# Altium schematic sheet ISource.SchDoc
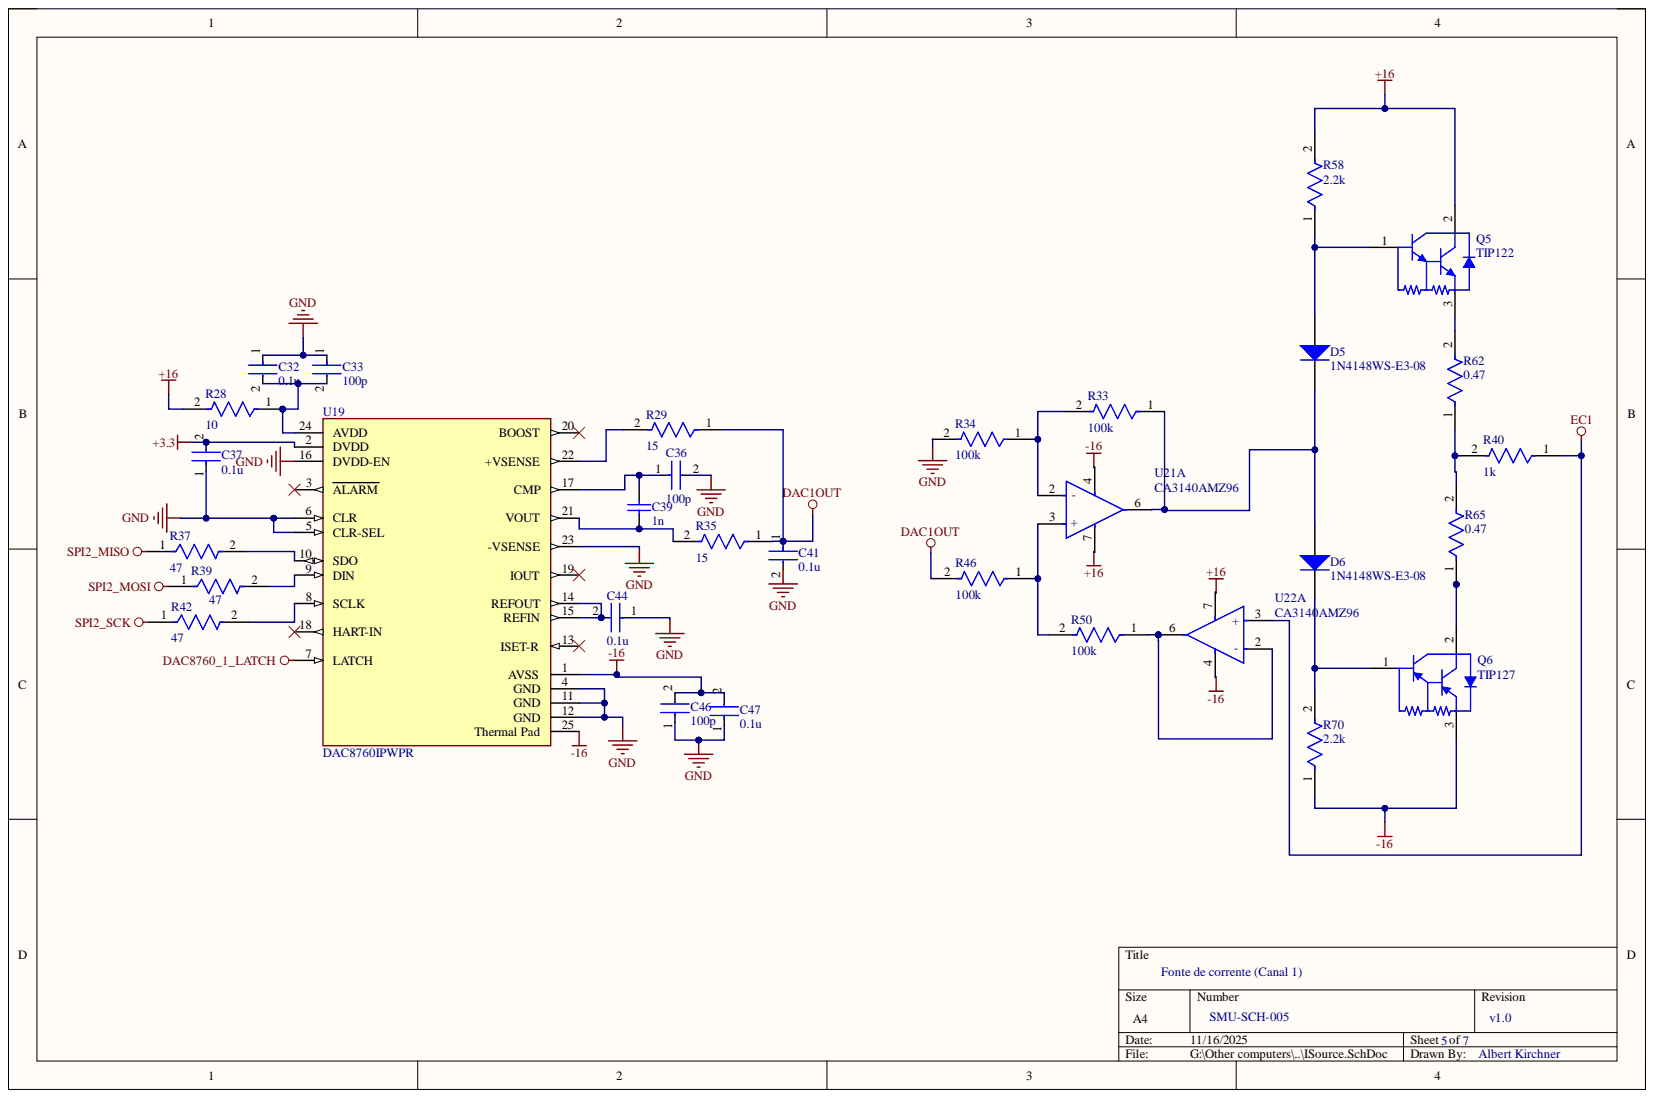
[diagram p0: ISource.SchDoc]

## Parts
C32  0.1u  [FP-CC0805-0_85-MFG]
C33  100p  [FP-0805-L_2_01_0_2-W_1_25-IPC_B]
C36  100p  [FP-0805-L_2_01_0_2-W_1_25-IPC_B]
C37  0.1u  [FP-CC0805-0_85-MFG]
C39  1n  [CAPC2013X70X45ML10T25]
C41  0.1u  [FP-CC0805-0_85-MFG]
C44  0.1u  [FP-CC0805-0_85-MFG]
C46  100p  [FP-0805-L_2_01_0_2-W_1_25-IPC_B]
C47  0.1u  [FP-CC0805-0_85-MFG]
D5  1N4148WS-E3-08  [VISH-SOD-323-2_V]
D6  1N4148WS-E3-08  [VISH-SOD-323-2_V]
Q5  TIP122  [ONSC-TO-220-3-221A-09]
Q6  TIP127  [ONSC-TO-220-3-221A-09]
R28  10  [FP-CR1206-MFG]
R29  15  [FP-CR1206-MFG]
R33  100k  [FP-CR1206-MFG]
R34  100k  [FP-CR1206-MFG]
R35  15  [FP-CR1206-MFG]
R37  47  [FP-CR1206-MFG]
R39  47  [FP-CR1206-MFG]
R40  1k  [FP-CRCW1210-e3-IPC_B]
R42  47  [FP-CR1206-MFG]
R46  100k  [FP-CR1206-MFG]
R50  100k  [FP-CR1206-MFG]
R58  2.2k  [FP-CRCW1210-e3-IPC_B]
R62  0.47  [FP-CRCW1210-e3-IPC_B]
R65  0.47  [FP-CRCW1210-e3-IPC_B]
R70  2.2k  [FP-CRCW1210-e3-IPC_B]
U19  DAC8760IPWPR  [FP-PWP0024J-IPC_A]
U21  CA3140AMZ96  [M8_15_MFG]  (part A)
U22  CA3140AMZ96  [M8_15_MFG]  (part A)

## Nets
+3.3: C37.2 U19.2(DVDD)
+16: Q5.2 R28.2 R58.2 U21.7 U22.7
-16: C46.2 C47.2 Q6.3 R70.1 U19.1(AVSS) U19.25(Thermal_Pad) U21.4 U22.4
DAC1OUT: C41.1 R29.1 R35.1 R46.2
DAC8760_1_LATCH: U19.7(LATCH)
EC1: R40.1 U22.3(+)
GND: C32.1 C33.1 C36.2 C37.1 C41.2 C44.1 C46.1 C47.1 R34.2 U19.4(GND) U19.5(CLR-SEL) U19.6(CLR) U19.11(GND) U19.12(GND) U19.16(DVDD-EN) U19.23(-VSENSE)
SPI2_MISO: R37.1
SPI2_MOSI: R39.1
SPI2_SCK: R42.1
NetC32_2: C32.2 C33.2 R28.1 U19.24(AVDD)
NetC36_1: C36.1 C39.2 U19.17(CMP)
NetC39_1: C39.1 R35.2 U19.21(VOUT)
NetC44_2: C44.2 U19.14(REFOUT) U19.15(REFIN)
NetD5_1: D5.1 Q5.1 R58.1
NetD5_2: D5.2 D6.1 R33.1 U21.6
NetD6_2: D6.2 Q6.1 R70.2
NetQ5_3: Q5.3 R62.2
NetQ6_2: Q6.2 R65.1
NetR29_2: R29.2 U19.22(+VSENSE)
NetR33_2: R33.2 R34.1 U21.2(-)
NetR37_2: R37.2 U19.10(SDO)
NetR39_2: R39.2 U19.9(DIN)
NetR40_2: R40.2 R62.1 R65.2
NetR42_2: R42.2 U19.8(SCLK)
NetR46_1: R46.1 R50.2 U21.3(+)
NetR50_1: R50.1 U22.2(-) U22.6

Not connected: U19 3(ALARM) 13(ISET-R) 18(HART-IN) 19(IOUT) 20(BOOST)

## Library data of the parts
C32 C37 C41 C44 C47 = CMP-2100-03606-3: CAP CER 0.1UF 50V X7R 0805; Manufacturer: Yageo; Manufacturer Part Number: CC0805KRX7R9BB104; Capacitance: 100 nF; Material: Ceramic; Tolerance: 0.1; Value: 100 nF; Automotive: No; Category: Cap; Component Kind: Standard; ComponentLink2Description: Datasheet; ComponentLink2URL: http://www.yageo.com/documents/recent/UPY-GPHC_Y5V_6.3V-to-50V_8.pdf; Device Class L1: Passive Components; Device Class L2: Capacitors; Device Class L3: Ceramic Capacitors; Digikey Description: CAP CER 0.1UF 50V X7R 0805; Footprint Url: http://www.yageo.com/exep/pages/download/literatures/UPY-C_GEN_24.pdf; Height: 0.95mm; Ipc Land Pattern Name: CAPC200125X85; Lead Free: Yes; Library Name: Altium Content Vault; Mouser Description: Multilayer Ceramic Capacitors MLCC - SMD/SMT 100nF 50V X7R 10%; Octopart: https://octopart.com/cc0805krx7r9bb104-yageo-192993; Package: CC0805-0.85; RoHS: TRUE; Temperature: -30°C to +85°C; Temperature Characteristic: X7R; Temperature Range High: +85°C; Temperature Range Low: -30°C; Voltage Rating: 50 V
C33 C36 C46 = CMP-2007-04119-2: General Purpose Ceramic Capacitor, 0805, 100pF, 1%, C0G, 30ppm/°C, 100V; Capacitance: 100pF; Case Code (Imperial): 0805; Case Code (Metric): 2012; Case/Package: 0805; Component Kind: Standard; Construction: Flat; Depth: 1.25mm; Dielectric: C0G; Dielectric Material: Ceramic; Height: 0.71mm; Lead Free: Lead Free; Length: 2.01mm; Library Name: Altium Content Vault; Max Operating Temperature: 125°C; Min Operating Temperature: -55°C; Mount: Surface Mount; Number of Pins: 2; Package Quantity: 1; Packaging: Tape and Reel; Radiation Hardening: No; REACH SVHC: No SVHC; RoHS Compliant: Yes; Series: -; Termination: SMD/SMT; Thickness: 0.037inch; Tolerance: 1%; Voltage: 100V; Voltage Rating: 100V; Voltage Rating (DC): 100V; Width: 1.25mm
C39 = CMP-2007-00997-1: Manufacturer: Murata; Part Number: GRM216R71H472KA01D; Component Kind: Standard; Library Name: Altium Content Vault
D5 D6 = CMP-2000-05517-2: Small Signal Fast Switching Diode, 1.2 V, 350 mA, -55 to 150 degC, 2-Pin SOD-323, RoHS, Tape and Reel; Manufacturer: Vishay; Brand: VishaySemiconductors; Forward Continuous Current: 150mA; Forward Voltage Drop: 1.2V; Max Surge Current: 350mA; Maximum Diode Capacitance: 4pF; Maximum Power Dissipation: 200mW; Maximum Reverse Leakage Current: 100uA; Package Version: Rev. 5, 09/2009; Peak Reverse Voltage: 100V; Recovery Time: 4ns; Unit Weight: 0.000152oz; Component Kind: Standard; Datasheet URL: http://www.vishay.com/docs/85751/1n4148ws.pdf; Datasheet Version: Rev. 2.0, 05/2013; Factory Pack Quantity: 3000; Library Name: Altium Content Vault; Manufacturer URL: http://www.vishay.com/; Maximum Operating Temperature: +150C; Minimum Operating Temperature: -55C; Mounting Style: SMD/SMT; Mounting Technology: SurfaceMount; Operating Temperature Range: -55Cto+150C; Package / Case: SOD-323; Package Description: 2-Pin Surface Mount Device, Body 1.775 x 1.3 mm, Height 1.15 mm; Package Reference: SOD-323-2; Package URL: http://www.st.com/st-web-ui/static/active/en/resource/technical/document/datasheet/CD00233088.pdf; Packaging: Reel; Product: SwitchingDiodes; Product Category: Diodes-GeneralPurpose,Power,Switching; RoHS: Details; Series: 1N4148WS
Q5 = CMP-1047-00126-1: Plastic Medium-Power, Complementary Silicon Transistor, 3-Pin TO-220, Tube; Manufacturer: On Semiconductor; IC Continuous (A): 5; Polarity: NPN; Component Kind: Standard; ComponentLink1Description: Manufacturer URL; ComponentLink1URL: http://www.onsemi.com/; ComponentLink2Description: Datasheet; ComponentLink2URL: http://www.onsemi.cn/pub_link/Collateral/TIP120-D.PDF; ComponentLink3Description: Package Specification; ComponentLink3URL: http://www.onsemi.com/pub_link/Collateral/221A-09.PDF; DatasheetVersion: Rev. 8; Library Name: Altium Content Vault; Mounting Technology: Through Hole; PackageDescription: 3-Pin Flange Mount, Vertical Straight Lead, Body 9.97 x 4.445 mm, Pitch 2.54 mm; PackageReference: TO-220-3-221A-09; PackageVersion: Rev. AG, 09/2011; Packing: Tube; PartNumber: TIP122; RoHS: FALSE
Q6 = CMP-1031-00026-1: Plastic Medium-Power, Complementary Silicon Transistor, 3-Pin TO-220, Tube; Manufacturer: On Semiconductor; IC Continuous (A): 5; Polarity: PNP; Component Kind: Standard; ComponentLink1Description: Manufacturer URL; ComponentLink1URL: http://www.onsemi.com/; ComponentLink2Description: Datasheet; ComponentLink2URL: http://www.onsemi.cn/pub_link/Collateral/TIP120-D.PDF; ComponentLink3Description: Package Specification; ComponentLink3URL: http://www.onsemi.com/pub_link/Collateral/221A-09.PDF; DatasheetVersion: Rev. 8; Library Name: Altium Content Vault; Mounting Technology: Through Hole; PackageDescription: 3-Pin Flange Mount, Vertical Straight Lead, Body 9.97 x 4.445 mm, Pitch 2.54 mm; PackageReference: TO-220-3-221A-09; PackageVersion: Rev. AG, 09/2011; Packing: Tube; PartNumber: TIP127; RoHS: FALSE
R28 R29 R33-R35 R37 R39 R42 R46 R50 = CMP-07231-003158-1: Thick Film Chip Resistors 1206 330Ω 0.25W 1% 100ppm/°C; Max Power Dissipation: 250mW; Resistance: 330R; Voltage Rating: 200V; Case Code (Imperial): 1206; Case Code (Metric): 3216; Case/Package: 1206; Component Kind: Standard; Composition: Thick Film; Contact Plating: Tin; Depth: 1.6mm; Height: 0.6mm; Length: 3.2mm; Library Name: Altium Content Vault; Max Operating Temperature: 155°C; Military Standard: Not; Min Operating Temperature: -55°C; Mount: Surface Mount; Number of Pins: 2; Number of Terminations: 2; Package Quantity: 5000; Packaging: Tape and Reel; Power Rating: 250mW; Radiation Hardening: No; REACH SVHC: No SVHC; Temperature Coefficient: 100ppm/°C; Termination: SMD/SMT; Thickness: 610um; Tolerance: 1%; Voltage Rating (DC): 200V; Width: 1.6mm
R40 R58 R62 R65 R70 = CMP-2005-01987-2: RES SMD 205K OHM 1% 1/2W 1992; Max Power Dissipation: 500mW; Resistance: 0mR; Temperature Coefficient: 0ppm/°C; Voltage Rating: 200V; Case Code (Imperial): 1210; Case Code (Metric): 3225; Case/Package: 1210; Component Kind: Standard; Composition: Thick Film; Contact Plating: Tin; Depth: 2.5mm; Features: Automotive AEC-Q200; Height: 0.55mm; Length: 3.2mm; Library Name: Altium Content Vault; Max Operating Temperature: 155°C; Military Standard: Not; Min Operating Temperature: -55°C; Mount: Surface Mount; Number of Terminations: 2; Package Quantity: 1; Packaging: Tape and Reel; Power Rating: 500mW; Radiation Hardening: No; REACH SVHC: No SVHC; Termination: SMD/SMT; Weight: 0.000564oz; Width: 0.098inch
U19 = CMP-04861-000014-1: IC DAC 16BIT V OR A-OUT 24HTSSOP; Differential Nonlinearity: 1LSB; Differential Output: No; Interface: SPI; Max Dual Supply Voltage: 18V; Max Power Dissipation: 170mW; Max Supply Voltage: 36V; Min Supply Voltage: 10V; Number of Bits: 16; Number of Channels: 1; Output Type: Voltage; Polarity: Bipolar; Power Consumption: 135mW; Resolution: 16b; Settling Time: 25us; Case/Package: HTSSOP EP; Component Kind: Standard; Contact Plating: Gold; Lead Free: Lead Free; Library Name: Altium Content Vault; Max Operating Temperature: 125°C; Min Operating Temperature: -40°C; Mount: Surface Mount; Number of Converters: 1; Number of D/A Converters: 1; Number of DAC Channels: 1; Number of Pins: 24; Package Quantity: 2000; Packaging: Tape and Reel; REACH SVHC: No SVHC; RoHS Compliant: Yes; Weight: 0.006081oz
U21 U22 = CMP-00572-88253531-2: CA3140 Series 36 V 4.5 MHz BiMOS Operational Amplifier - SOIC-8; Manufacturer Lifecycle Status: ACTIVE; Bandwidth: 4.5MHz; Common Mode Rejection Ratio: 90dB; Dual Supply Voltage: 9V; Gain Bandwidth Product: 3.7MHz; Input Bias Current: 10pA; Input Offset Voltage (Vos): 2mV; Max Dual Supply Voltage: 18V; Max Supply Voltage: 36V; Min Dual Supply Voltage: 2V; Min Supply Voltage: 4V; Nominal Supply Current: 1.6mA; Number of Channels: 1; Operating Supply Current: 4mA; Operating Supply Voltage: 28V; Output Current: 10mA; Output Current per Channel: 40mA; Pitch: 1.3mm; Power Dissipation: 180mW; Power Supply Rejection Ratio (PSRR): 80dB; RAM Size: 640kB; Slew Rate: 9V/µs; Voltage Gain: 100dB; Case/Package: SOIC; Component Kind: Standard; ComponentLink1Description: Manufacturer's Website; ComponentLink1URL: http://www.renesas.com; ComponentLink2Description: Datasheet URL; ComponentLink2URL: https://octopart.com/cad-content/api/get-model-datasheet/15189/88253531; ComponentLink3Description: Manufacturer's Package Drawing; ComponentLink3URL: https://www.renesas.com/en/document/psc/m815-8-lead-narrow-body-small-outline-plastic-package; Contact Plating: Tin; Height: 0m; HelpURL: https://octopart.com/cad-content/api/get-model-datasheet/15189/88253531; Lead Free: Lead Free; Length: 4.9mm; Library Name: Altium Content Vault; Lifecycle Status: Production; Max Operating Temperature: 85°C; Min Operating Temperature: -40°C; Mount: Surface Mount; Number of Circuits: 1; Number of Pins: 8; Radiation Hardening: No; RoHS: Compliant; Schedule B: 8542330000; Width: 3.9mm
